# Altium schematic sheet analog.SchDoc(analog)
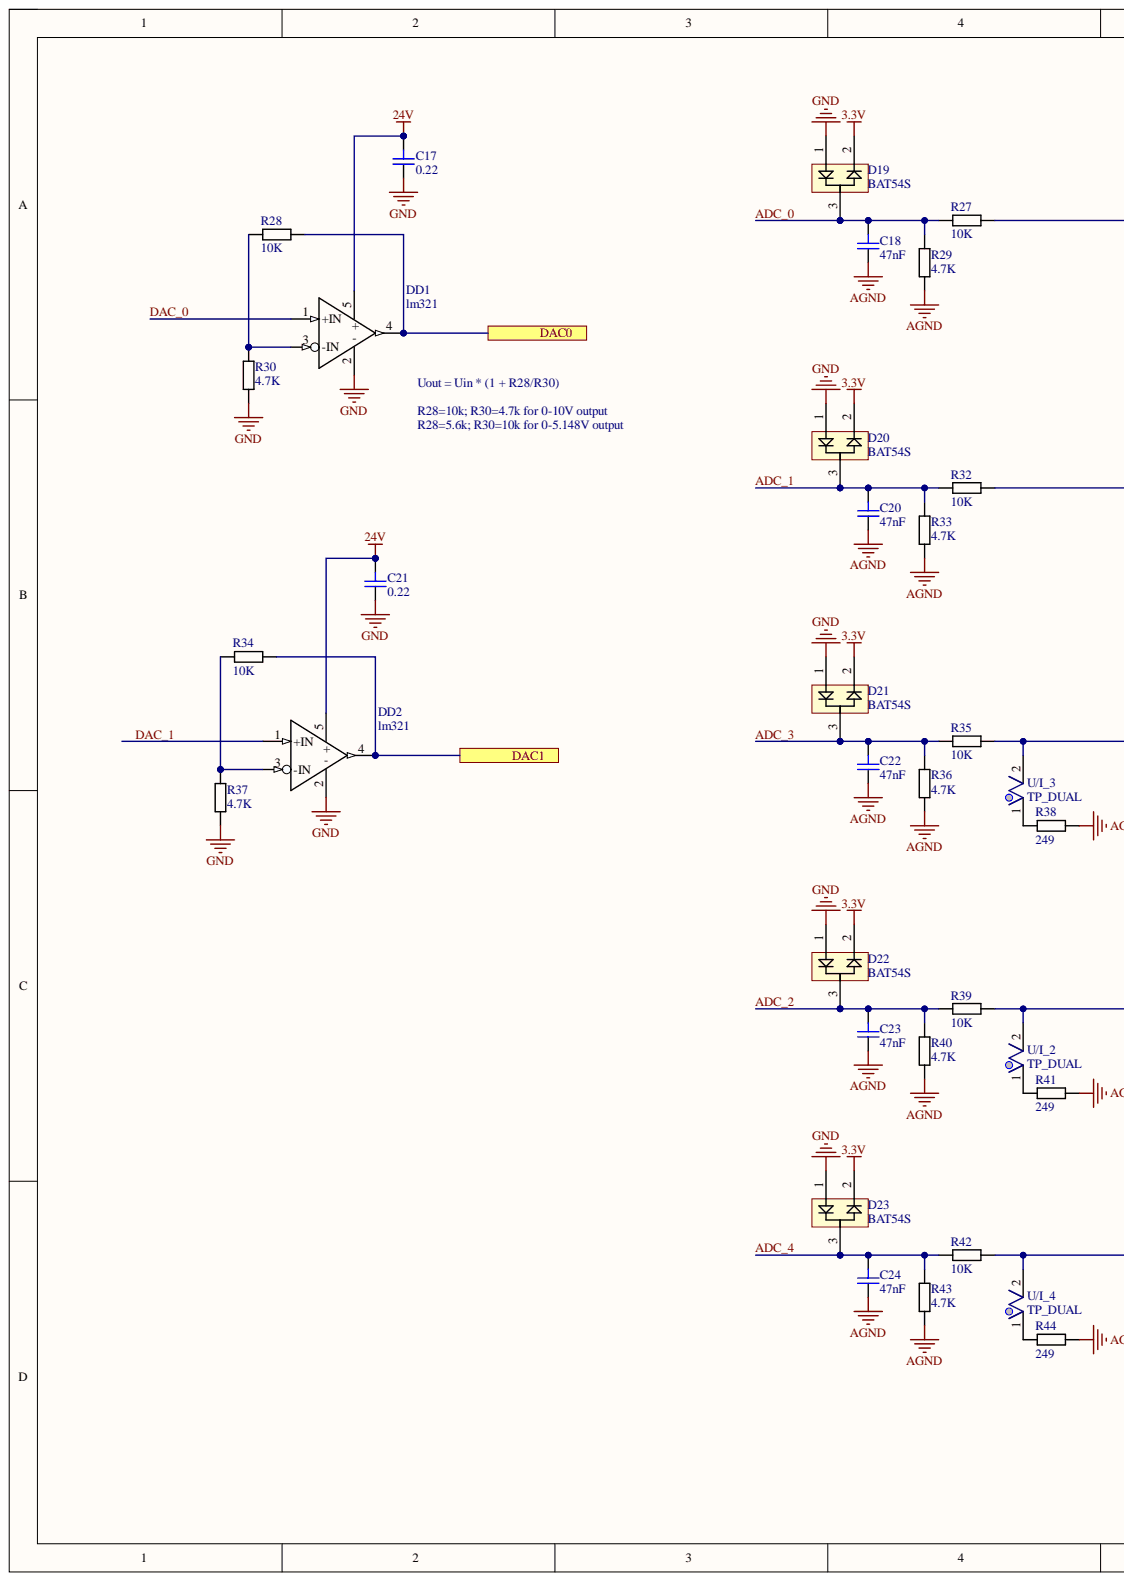
[diagram p0: analog.SchDoc, left]
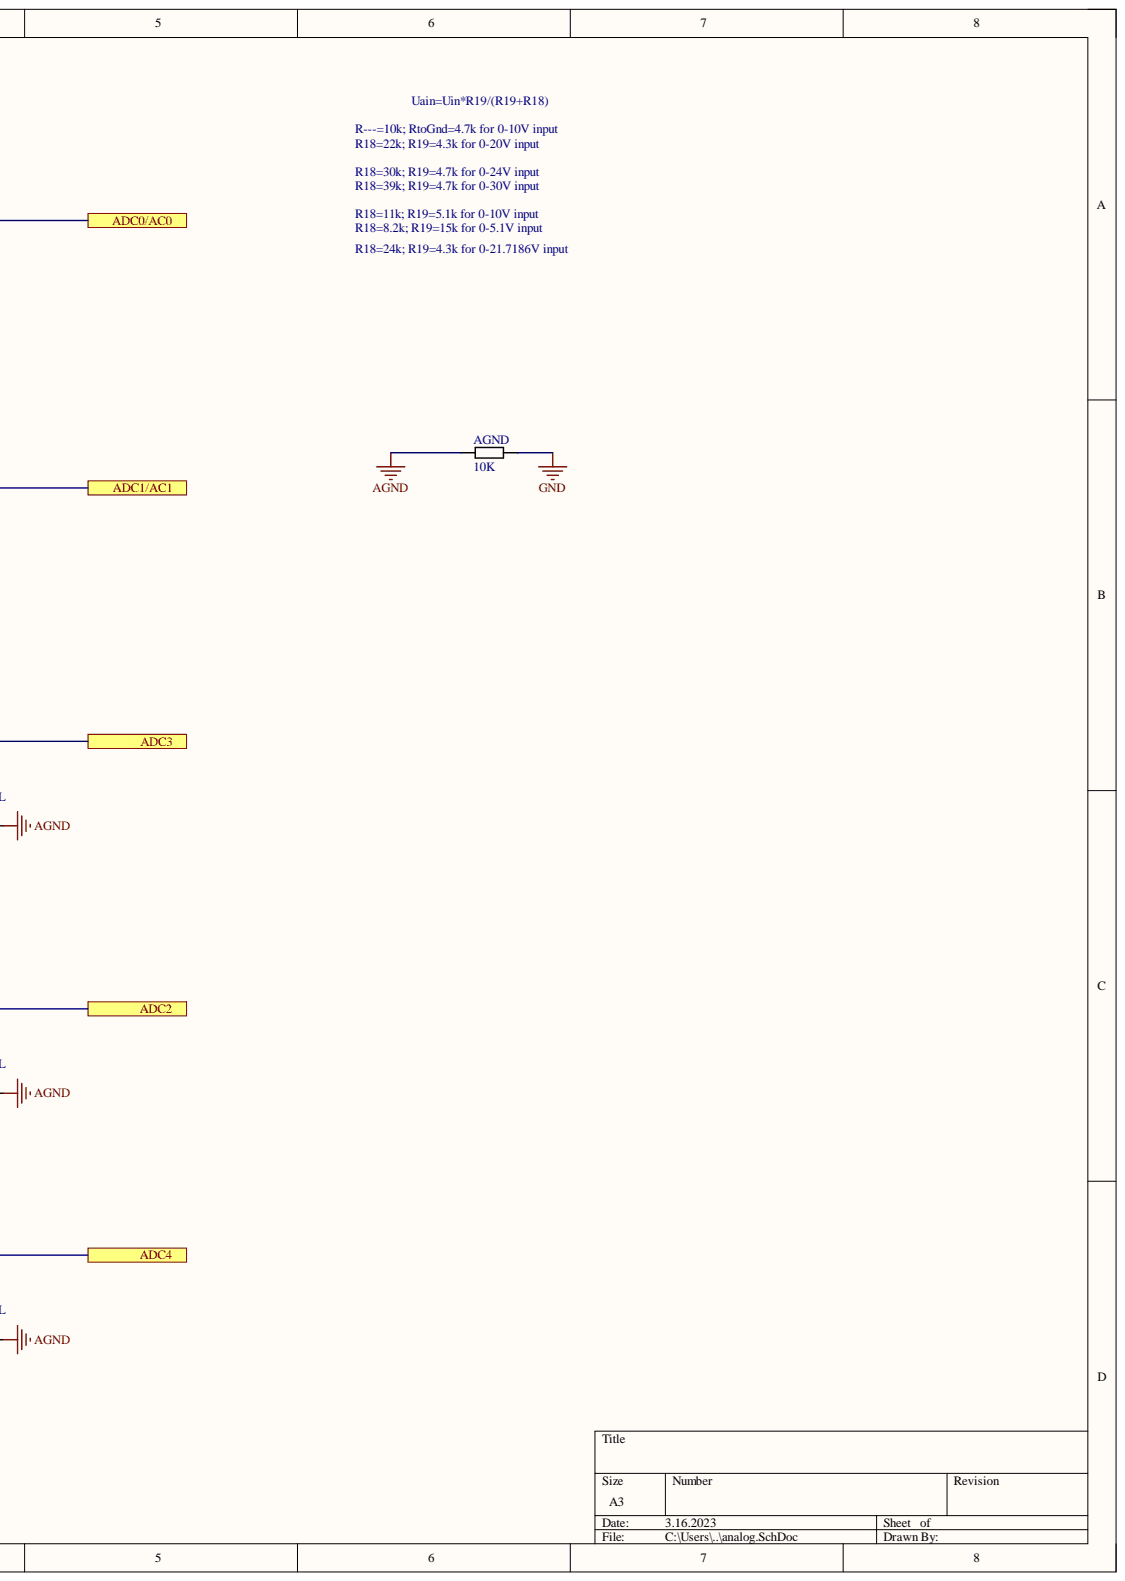
[diagram p1: analog.SchDoc, right]

## Parts
AGND  value 10K  [RESC2012L]  (hidden comment: Res3)
C17  value 0.22  [CAPC2012L]  (hidden comment: Cap2)
C18  value 47nF  [CAPC2012L]  (hidden comment: Cap2)
C20  value 47nF  [CAPC2012L]  (hidden comment: Cap2)
C21  value 0.22  [CAPC2012L]  (hidden comment: Cap2)
C22  value 47nF  [CAPC2012L]  (hidden comment: Cap2)
C23  value 47nF  [CAPC2012L]  (hidden comment: Cap2)
C24  value 47nF  [CAPC2012L]  (hidden comment: Cap2)
D19  BAT54S  [SOT23-3L]
D20  BAT54S  [SOT23-3L]
D21  BAT54S  [SOT23-3L]
D22  BAT54S  [SOT23-3L]
D23  BAT54S  [SOT23-3L]
DD1  lm321  [SOT23-5AN]
DD2  lm321  [SOT23-5AN]
R27  value 10K  [RESC2012L]  (hidden comment: Res3)
R28  value 10K  [RESC2012L]  (hidden comment: Res3)
R29  value 4.7K  [RESC2012L]  (hidden comment: Res3)
R30  value 4.7K  [RESC2012L]  (hidden comment: Res3)
R32  value 10K  [RESC2012L]  (hidden comment: Res3)
R33  value 4.7K  [RESC2012L]  (hidden comment: Res3)
R34  value 10K  [RESC2012L]  (hidden comment: Res3)
R35  value 10K  [RESC2012L]  (hidden comment: Res3)
R36  value 4.7K  [RESC2012L]  (hidden comment: Res3)
R37  value 4.7K  [RESC2012L]  (hidden comment: Res3)
R38  value 249  [RESC2512-1206]  (hidden comment: Res3)
R39  value 10K  [RESC2012L]  (hidden comment: Res3)
R40  value 4.7K  [RESC2012L]  (hidden comment: Res3)
R41  value 249  [RESC2512-1206]  (hidden comment: Res3)
R42  value 10K  [RESC2012L]  (hidden comment: Res3)
R43  value 4.7K  [RESC2012L]  (hidden comment: Res3)
R44  value 249  [RESC2512-1206]  (hidden comment: Res3)
U/I_2  TP_DUAL  [TP_DUAL]
U/I_3  TP_DUAL  [TP_DUAL]
U/I_4  TP_DUAL  [TP_DUAL]

## Ports
ADC0/AC0, ADC1/AC1, ADC2, ADC3, ADC4, DAC0, DAC1

## Nets
3.3V: D19.2 D20.2 D21.2 D22.2 D23.2
24V: C17.2 C21.2 DD1.5(+) DD2.5(+)
AGND: AGND.2 C18.1 C20.1 C22.1 C23.1 C24.1 R29.1 R33.1 R36.1 R38.1 R40.1 R41.1 R43.1 R44.1
GND: AGND.1 C17.1 C21.1 D19.1 D20.1 D21.1 D22.1 D23.1 DD1.2(-) DD2.2(-) R30.2 R37.2
ADC0/AC0: R27.1 ; port ADC0/AC0
ADC1/AC1: R32.1 ; port ADC1/AC1
ADC2: R39.1 U/I_2.2 ; port ADC2
ADC3: R35.1 U/I_3.2 ; port ADC3
ADC4: R42.1 U/I_4.2 ; port ADC4
ADC_0: C18.2 D19.3 R27.2 R29.2
ADC_1: C20.2 D20.3 R32.2 R33.2
ADC_2: C23.2 D22.3 R39.2 R40.2
ADC_3: C22.2 D21.3 R35.2 R36.2
ADC_4: C24.2 D23.3 R42.2 R43.2
DAC0: DD1.4 R28.1 ; port DAC0
DAC1: DD2.4 R34.1 ; port DAC1
DAC_0: DD1.1(+IN)
DAC_1: DD2.1(+IN)
NetDD1_3: DD1.3(-IN) R28.2 R30.1
NetDD2_3: DD2.3(-IN) R34.2 R37.1
NetR38_2: R38.2 U/I_3.1
NetR41_2: R41.2 U/I_2.1
NetR44_2: R44.2 U/I_4.1

## Library data of the parts
AGND R27-R30 R32-R44 = Res3: Resistor; Component Kind: Standard; LatestRevisionDate: 29-May-2009; LatestRevisionNote: IPC-7351 Footprint Added.; Library Name: SA_AltiumSchLib.SchLib; PackageDescription: Chip Resistor; PackageReference: J1-0603; Published: 8-Jun-2000; Signal Integrity: Res; Simulation: RESISTOR; Publisher: Altium Limited
C17 C18 C20-C24 = Cap2: Capacitor; Component Kind: Standard; Library Name: SA_AltiumSchLib.SchLib; PackageReference: CAPR5-4X5; Published: 23-Sep-2002; Signal Integrity: Cap; Simulation: CAP; Publisher: Altium Limited
D19-D23 = BAT54S: Dual small signal Schottky diode; Forward Continious Current: 200 mA; Component Kind: Standard; Library Name: SA_AltiumSchLib.SchLib
DD1 DD2 = lm321: Operational Amplifiers 32v; Component Kind: Standard; Library Name: SA_AltiumSchLib.SchLib
U/I_2-U/I_4 = TP_DUAL: dual test point; Component Kind: Standard; Library Name: SA_AltiumSchLib.SchLib
